# Altium schematic sheet Clock Gen.SchDoc("Clock Gen")
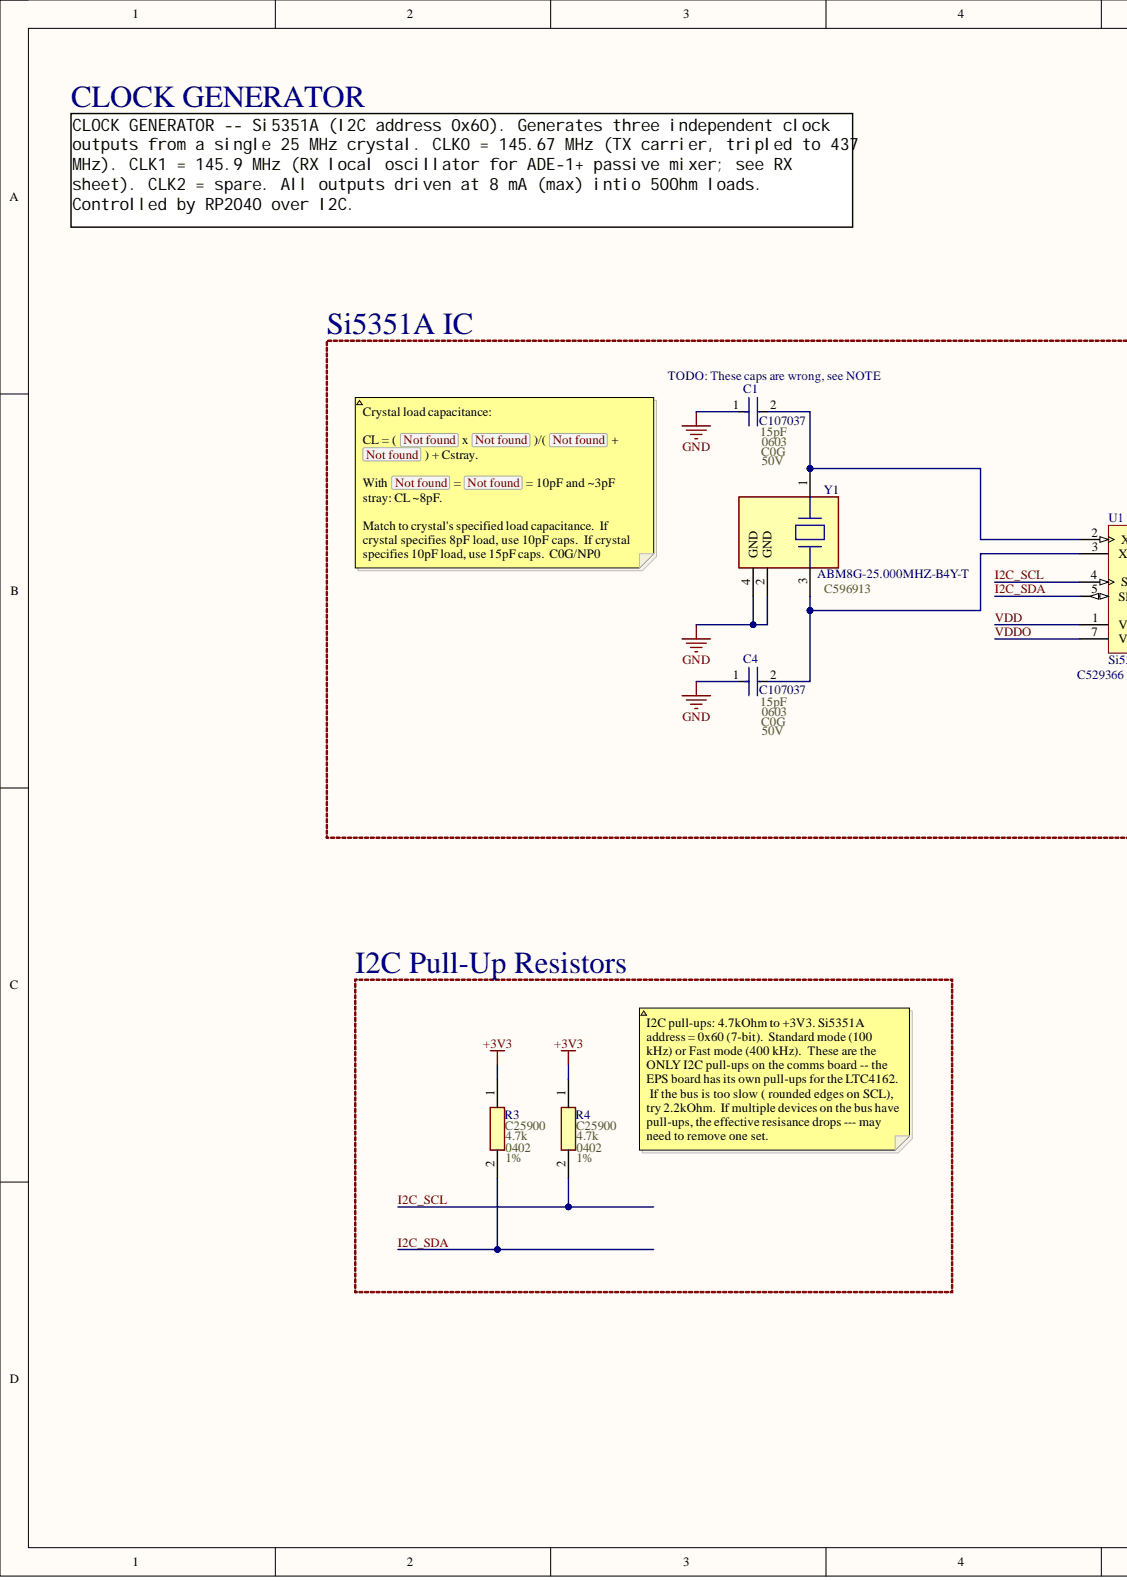
[diagram p0: Clock Gen.SchDoc, left]
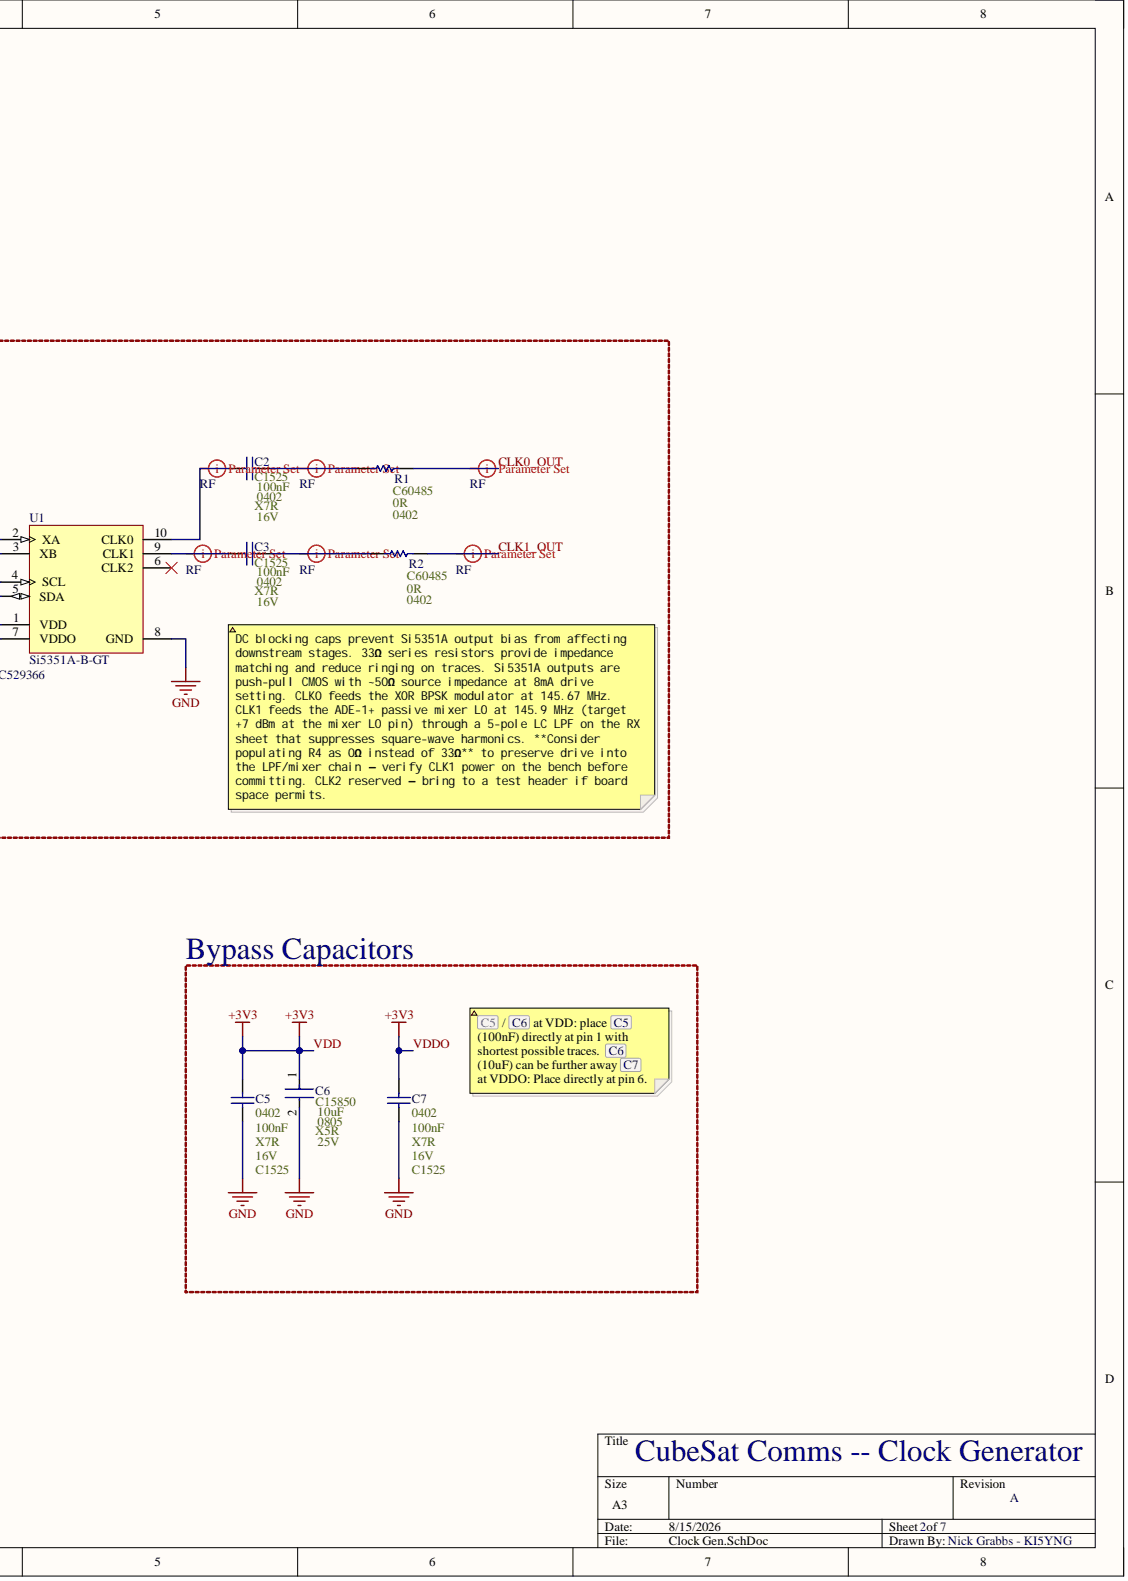
[diagram p1: Clock Gen.SchDoc, right]

## Parts
C1  Capacitance: 15pF  Case Code (Imperial): 0603  LCSC Part #: C107037  (hidden comment: CC0603JRNPO9BN150)
C2  Capacitance: 100nF  Case Size/Dimension: 0402  Dielectric: X7R  LCSC Part #: C1525  (hidden comment: CL05B104KO5NNNC)
C3  Capacitance: 100nF  Case Size/Dimension: 0402  Dielectric: X7R  LCSC Part #: C1525  Rated Voltage: 16V  (hidden comment: CL05B104KO5NNNC)
C4  Capacitance: 15pF  Case Code (Imperial): 0603  Dielectric: C0G  LCSC Part #: C107037  Voltage Rating: 50V  (hidden comment: CC0603JRNPO9BN150)
C5  Capacitance: 100nF  Case Size/Dimension: 0402  Dielectric: X7R  Rated Voltage: 16V  (hidden comment: CL05B104KO5NNNC)
C6  Capacitance: 10uF  Case Code (Imperial): 0805  Dielectric: X5R  LCSC Part #: C15850  Voltage Rating: 25V  (hidden comment: CL21A106KAYNNNE)
C7  Capacitance: 100nF  Case Size/Dimension: 0402  Dielectric: X7R  Rated Voltage: 16V  (hidden comment: CL05B104KO5NNNC)
R1  value 0R  LCSC Part #: C60485  (hidden comment: RC0402JR-070RL)
R2  value 0R  Case: 0402  LCSC Part #: C60485  (hidden comment: RC0402JR-070RL)
R3  value 4.7k  Case: 0402  LCSC Part #: C25900  Tolerance: 1%  (hidden comment: 0402WGF4701TCE)
R4  value 4.7k  Case: 0402  LCSC Part #: C25900  Tolerance: 1%  (hidden comment: 0402WGF4701TCE)
U1  Si5351A-B-GT  LCSC Part #: C529366
Y1  ABM8G-25.000MHZ-B4Y-T  LCSC Part #: C596913

## Nets
GND: C1.1 C4.1 C5.1 C6.2 C7.1 U1.8(GND) Y1.2(GND) Y1.4(GND)
CLK0_OUT: R1.2
CLK1_OUT: R2.2
I2C_SCL: R4.2 U1.4(SCL)
I2C_SDA: R3.2 U1.5(SDA)
VDD: C5.2 C6.1 C7.2 R3.1 R4.1 U1.1(VDD) U1.7(VDDO)
NetC1_2: C1.2 U1.2(XA) Y1.1
NetC2_1: C2.1 U1.10(CLK0)
NetC2_2: C2.2 R1.1
NetC3_1: C3.1 U1.9(CLK1)
NetC3_2: C3.2 R2.1
NetC4_2: C4.2 U1.3(XB) Y1.3

Not connected: U1 6(CLK2)

## Library data of the parts
C1 = CMP-03422-001493-1: CAP CER 15PF 50V C0G/NPO 0603; Dielectric: C0G; Dielectric Material: Ceramic; Insulation Resistance: 100GR; Tolerance: 5%; Case Code (Metric): 1608; Case/Package: 0603; Component Kind: Standard; Depth: 0.8mm; Height: 0.8mm; Lead Free: Lead Free; Length: 1.6mm; Library Name: Altium Content Vault; Max Operating Temperature: 125°C; Min Operating Temperature: -55°C; Mount: Surface Mount; Number of Pins: 2; Package Quantity: 1; Packaging: Tape and Reel; Radiation Hardening: No; REACH SVHC: No SVHC; RoHS Compliant: Yes; Series: CC; Termination: SMD/SMT; Thickness: 0.035inch; Voltage Rating: 50V; Width: 0.031inch
C2 = CMP-2000-05439-1: Chip Capacitor, 100 nF, +/- 10%, 16 V, -55 to 125 degC, 0402 (1005 Metric), RoHS, Tape and Reel; Manufacturer: Samsung Electro-Mechanics; Rated Voltage: 16V; Component Kind: Standard; Datasheet URL: https://datasheet.ciiva.com/29198/458718-da-01-en-vs-kondensator-0402-29198423.pdf; Datasheet Version: Nov-10; Library Name: Altium Content Vault; Manufacturer URL: http://www.samsungsem.com/global/index.jsp; Tolerance (%): ±10%
C3 C5 C7 = CMP-2000-05439-1: Chip Capacitor, 100 nF, +/- 10%, 16 V, -55 to 125 degC, 0402 (1005 Metric), RoHS, Tape and Reel; Manufacturer: Samsung Electro-Mechanics; Component Kind: Standard; Datasheet URL: https://datasheet.ciiva.com/29198/458718-da-01-en-vs-kondensator-0402-29198423.pdf; Datasheet Version: Nov-10; Library Name: Altium Content Vault; Manufacturer URL: http://www.samsungsem.com/global/index.jsp; Tolerance (%): ±10%
  C5 C7: LCSC Part #: C1525
C4 = CMP-03422-001493-1: CAP CER 15PF 50V C0G/NPO 0603; Dielectric Material: Ceramic; Insulation Resistance: 100GR; Tolerance: 5%; Case Code (Metric): 1608; Case/Package: 0603; Component Kind: Standard; Depth: 0.8mm; Height: 0.8mm; Lead Free: Lead Free; Length: 1.6mm; Library Name: Altium Content Vault; Max Operating Temperature: 125°C; Min Operating Temperature: -55°C; Mount: Surface Mount; Number of Pins: 2; Package Quantity: 1; Packaging: Tape and Reel; Radiation Hardening: No; REACH SVHC: No SVHC; RoHS Compliant: Yes; Series: CC; Termination: SMD/SMT; Thickness: 0.035inch; Width: 0.031inch
C6 = CMP-13271-002975-1: CL21 Series 0805 10uF 25V ±10% Tolerance X5R Multilayer Ceramic Chip Capacitor; Case Code (Metric): 2012; Case/Package: 0805; Component Kind: Standard; Depth: 1.25mm; Height: 1.25mm; Length: 2mm; Library Name: Altium Content Vault; Max Operating Temperature: 85°C; Min Operating Temperature: -55°C; Mount: Surface Mount; Package Quantity: 1; Packaging: Tape and Reel; Radiation Hardening: No; Thickness: 0.057inch; Tolerance: 10%; Width: 0.049inch
R1 R2 = CMP-1011-00001-2: Manufacturer: Yageo / Phycomp; Component Kind: Standard; Library Name: Altium Content Vault; Part Number: RC0402JR-070RL
  R1: Case: 0402
R3 R4 = 0402WGF4701TCE: Resistor; Manufacturer_Name: UNI-ROYAL(Uniroyal Elec); Component Kind: Standard; Datasheet Link: https://datasheet.lcsc.com/szlcsc/Uniroyal-Elec-0402WGF4701TCE_C25900.pdf; Height: 0.4mm; Manufacturer_Part_Number: 0402WGF4701TCE
U1 = CMP-0510-00012-1: I2C-Programmable Any-Frequency CMOS Clock Generator + VCXO, 2.5 / 3.3 V, 0.008 to 160 MHz Output, -40 to 85 degC, 10-pin SOP; Manufacturer: Silicon Labs; Output Format: LVCMOS; Reference Inputs: 1; Sub-Family: Clock Generators; VDD: 2.5 V; 3.3 V; Code_IPC: J-STD-020; Code_JEDEC: MO-187; Component Kind: Standard; ComponentLink1Description: Manufacturer URL; ComponentLink1URL: http://www.silabs.com/; ComponentLink2Description: Datasheet; ComponentLink2URL: http://www.silabs.com/Support%20Documents/TechnicalDocs/Si5351.pdf; ComponentLink3Description: Package Specification; ComponentLink3URL: http://www.silabs.com/Support Documents/TechnicalDocs/C8051T60x.pdf; DatasheetVersion: Rev. 0.95; Library Name: Altium Content Vault; Mounting Technology: Surface Mount; Output Frequency (MHz): 0.008 to 160; PackageDescription: 10-Pin Mini Small Outline Package, Body 3 x 3 mm, Pitch 0.5 mm; PackageReference: MSOP-10; PartNumber: Si5351A-B-GT
Y1 = CMP-27762-000021-2: Crystal 25MHz ±30ppm (Tol) ±30ppm (Stability) 10pF FUND 60Ω 4-Pin SMD T/R; ESR (Equivalent Series Resistance): 60R; Case/Package: CSMD; Component Kind: Standard; Depth: 2.5mm; Frequency: 25MHz; Frequency Stability: 30ppm; Frequency Tolerance: 30ppm; Height: 0.039inch; Lead Free: Lead Free; Length: 3.2mm; Library Name: Altium Content Vault; Load Capacitance: 10pF; Max Operating Temperature: 70°C; Min Operating Temperature: -20°C; Mount: Surface Mount; Number of Pins: 4; Operating Frequency: 25 MHz; Package Quantity: 1000; Packaging: Tape and Reel; Series: ABM8G; Series Resistance: 60R; Termination: SMD/SMT; Tolerance: 30ppm; Width: 0.098inch
